# Altium schematic sheet SLA-FPC-BOSON_PAGE01.SchDoc
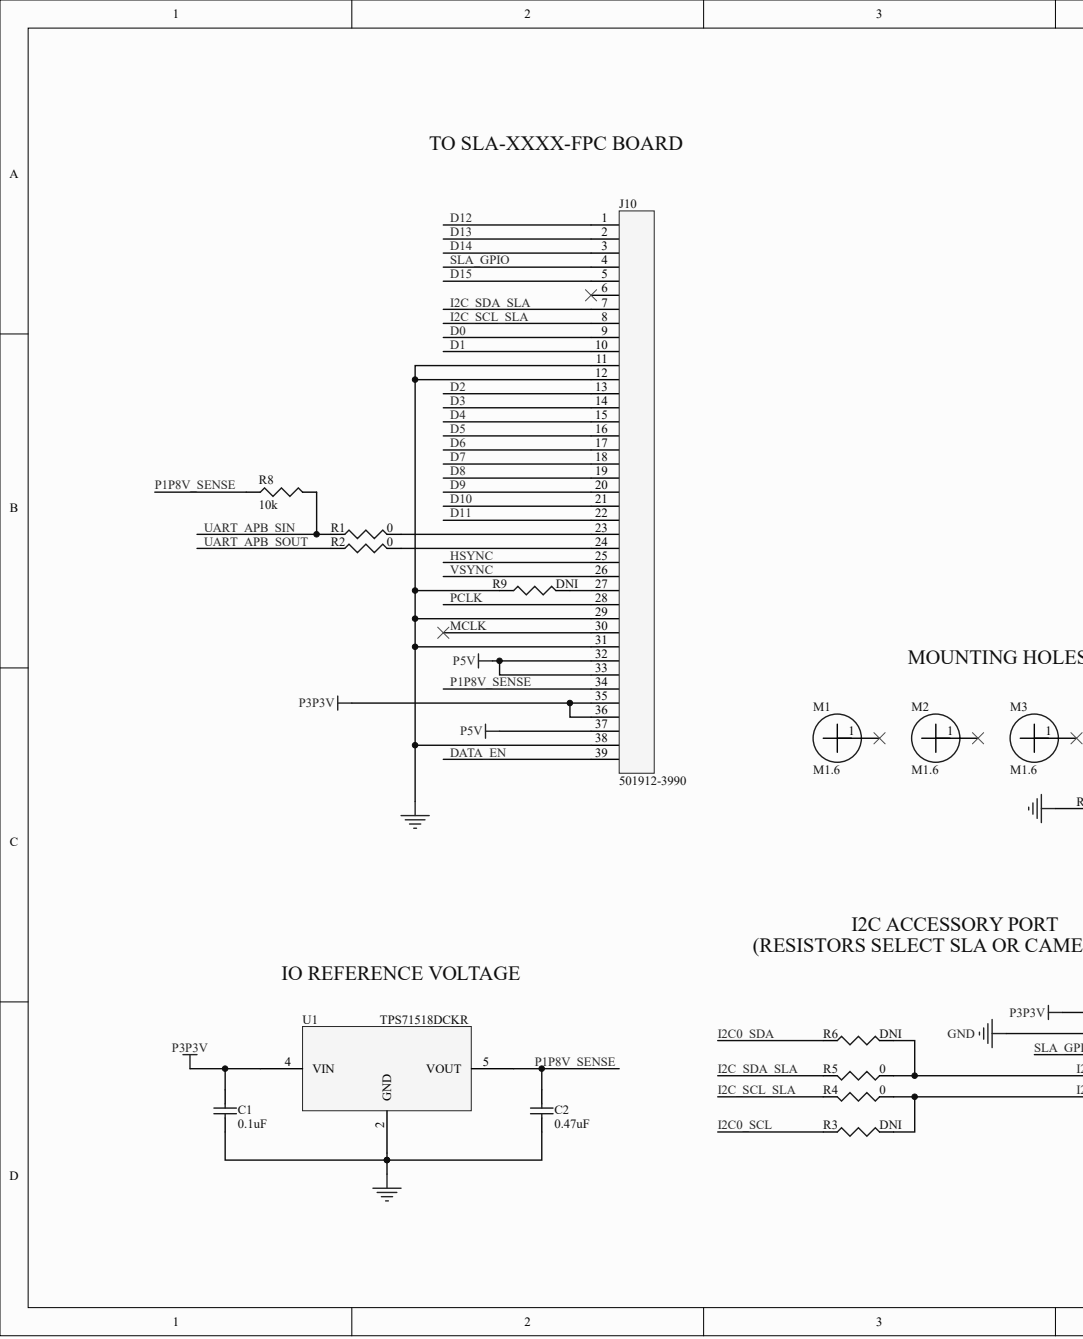
[diagram p0: SLA-FPC-BOSON_PAGE01.SchDoc, left]
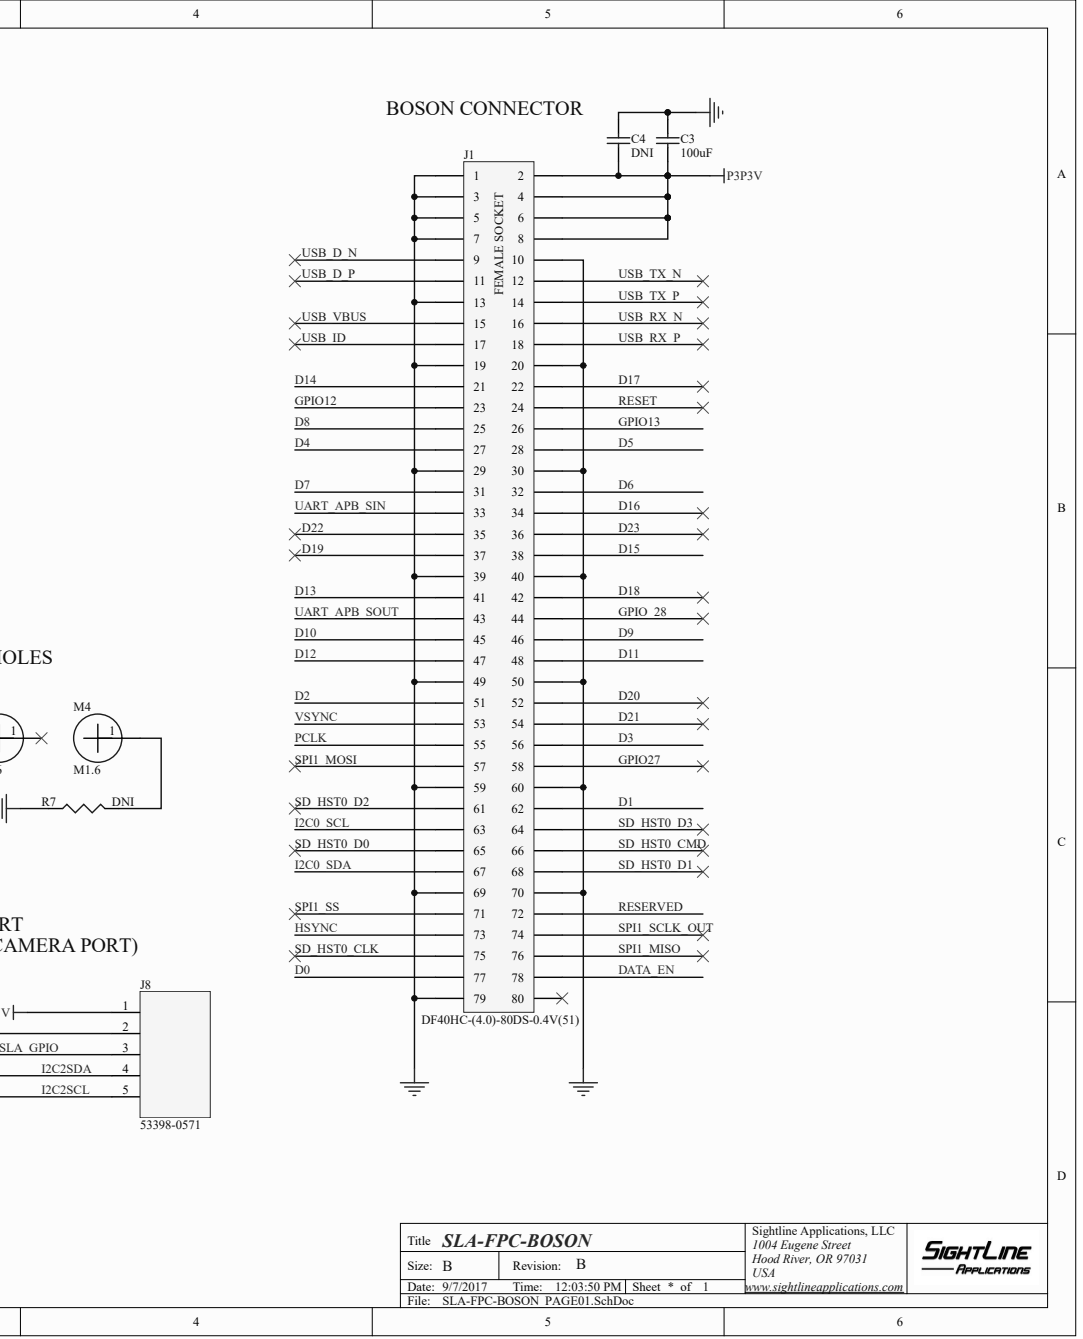
[diagram p1: SLA-FPC-BOSON_PAGE01.SchDoc, right]

## Parts
C1  0.1uF
C2  0.47uF
C3  100uF
C4  DNI
J1  DF40HC-(4.0)-80DS-0.4V(51)
J8  53398-0571
J10  501912-3990
M1  M1.6
M2  M1.6
M3  M1.6
M4  M1.6
R1  0  (value not drawn)
R2  0  (value not drawn)
R3  DNI
R4  0  (value not drawn)
R5  0  (value not drawn)
R6  DNI
R7  DNI
R8  10k
R9  DNI
U1  TPS71518DCKR

## Nets
GND: C1.1 C2.1 C3.2 C4.2 J1.1 J1.3 J1.5 J1.7 J1.10 J1.13 J1.19 J1.20 J1.29 J1.30 J1.39 J1.40 J1.49 J1.50 J1.59 J1.60 J1.69 J1.70 J1.79 J8.2 J10.11 J10.12 J10.29 J10.31 J10.38 R7.1 R9.1 U1.2
P3P3V: C1.2 C3.1 C4.1 J1.2 J1.4 J1.6 J1.8 J8.1 J10.35 J10.36 U1.4
P5V: J10.32 J10.33 J10.37
D0: J1.77 J10.9
D1: J1.62 J10.10
D2: J1.51 J10.13
D3: J1.56 J10.14
D4: J1.27 J10.15
D5: J1.28 J10.16
D6: J1.32 J10.17
D7: J1.31 J10.18
D8: J1.25 J10.19
D9: J1.46 J10.20
D10: J1.45 J10.21
D11: J1.48 J10.22
D12: J1.47 J10.1
D13: J1.41 J10.2
D14: J1.21 J10.3
D15: J1.38 J10.5
DATA_EN: J1.78 J10.39
HSYNC: J1.73 J10.25
I2C0_SCL: J1.63 R3.1
I2C0_SDA: J1.67 R6.1
I2C2SCL: J8.5 R3.2 R4.2
I2C2SDA: J8.4 R5.2 R6.2
I2C_SCL_SLA: J10.8 R4.1
I2C_SDA_SLA: J10.7 R5.1
P1P8V_SENSE: C2.2 J10.34 R8.1 U1.5
PCLK: J1.55 J10.28
SLA_GPIO: J8.3 J10.4
UART_APB_SIN: J1.33 R1.1 R8.2
UART_APB_SOUT: J1.43 R2.1
VSYNC: J1.53 J10.26
NetJ10_23: J10.23 R1.2
NetJ10_24: J10.24 R2.2
NetJ10_27: J10.27 R9.2
NetM4_1: M4.1 R7.2

Not connected: J1 9 11 12 14 15 16 17 18 22 23 24 26 34 35 36 37 42 44 52 54 57 58 61 64 65 66 68 71 72 74 75 76 80; J10 6 30; M1 1; M2 1; M3 1

## Library data of the parts
C1 = (no symbol name): MfgPartNo: GRM155R71C104KA88D; SLA Part No: SL00316; Mfg: Murata; Type: Ceramic - X7R; Capacitance: 0.1uF; Package: 402
C2 = (no symbol name): MfgPartNo: C0603C474K4RACTU; SLA Part No: SL00008; Mfg: Kemet; Type: Ceramic - X7R; Capacitance: 0.47uF; Package: 603
C3 = (no symbol name): MfgPartNo: C1206C107M9PACTU; SLA Part No: SL00005; Mfg: Kemet; Type: Ceramic - X5R; Package: 1206; Capacitance: 100uF
C4 = (no symbol name): Capacitance: NA; Mfg: NA; MfgPartNo: DNI; Mounting: 1206; Tolerance: NA; Type: NA
J1 = (no symbol name): SLA Part No: SL00747; Gender: Female; Mfg: Hirose; MfgPartNo: DF40HC-(4.0)-80DS-0.4V(51); Mounting: SMT; HelpURL: http://www.digikey.com/product-detail/en/hirose-electric-co-ltd/DF40HC(4.0)-80DS-0.4V(51)/H11919CT-ND/2530301
J8 = (no symbol name): SLA Part No: SL00454; Gender: Male; Mfg: Molex; HelpURL: http://www.molex.com/molex/products/datasheet.jsp?part=active/0533980571_PCB_HEADERS.xml; MfgPartNo: 53398-0571; Mounting: SMT
J10 = (no symbol name): SLA Part No: SL00670; Gender: Female; Mfg: Molex; MfgPartNo: 501912-3990; Mounting: SMT
M1-M4 = (no symbol name): Mfg: NA; MfgPartNo: M1.6
R1 R2 R4 R5 = (no symbol name): MfgPartNo: ERJ-2GE0R00X; SLA Part No: SL00128; Mfg: Panasonic; Package: 402; Resistance: 0
R8 = (no symbol name): MfgPartNo: ERJ-2RKF1002X; SLA Part No: SL00225; Mfg: Panasonic; Package: 402; Resistance: 10k
R9 = (no symbol name): SLA Part No: SL00128; OldMfgPartNo: ERJ-2GE0R00X; Mfg: Panasonic; MfgPartNo: DNI; Package: 402; Resistance: DNI
U1 = (no symbol name): SLA Part No: SL00260; Mfg: Texas Instruments; HelpURL: http://www.ti.com/lit/ds/symlink/tps71533.pdf; MfgPartNo: TPS71518DCKR; Package: SC-70-5
R3 R6 R7 = (no symbol name): Mfg: DNI; MfgPartNo: DNI; Resistance: DNI
  R3 R6: Package: 603
  R7: Package: 402
